# Altium schematic sheet Power_Regulation.SchDoc(Power_Regulation)
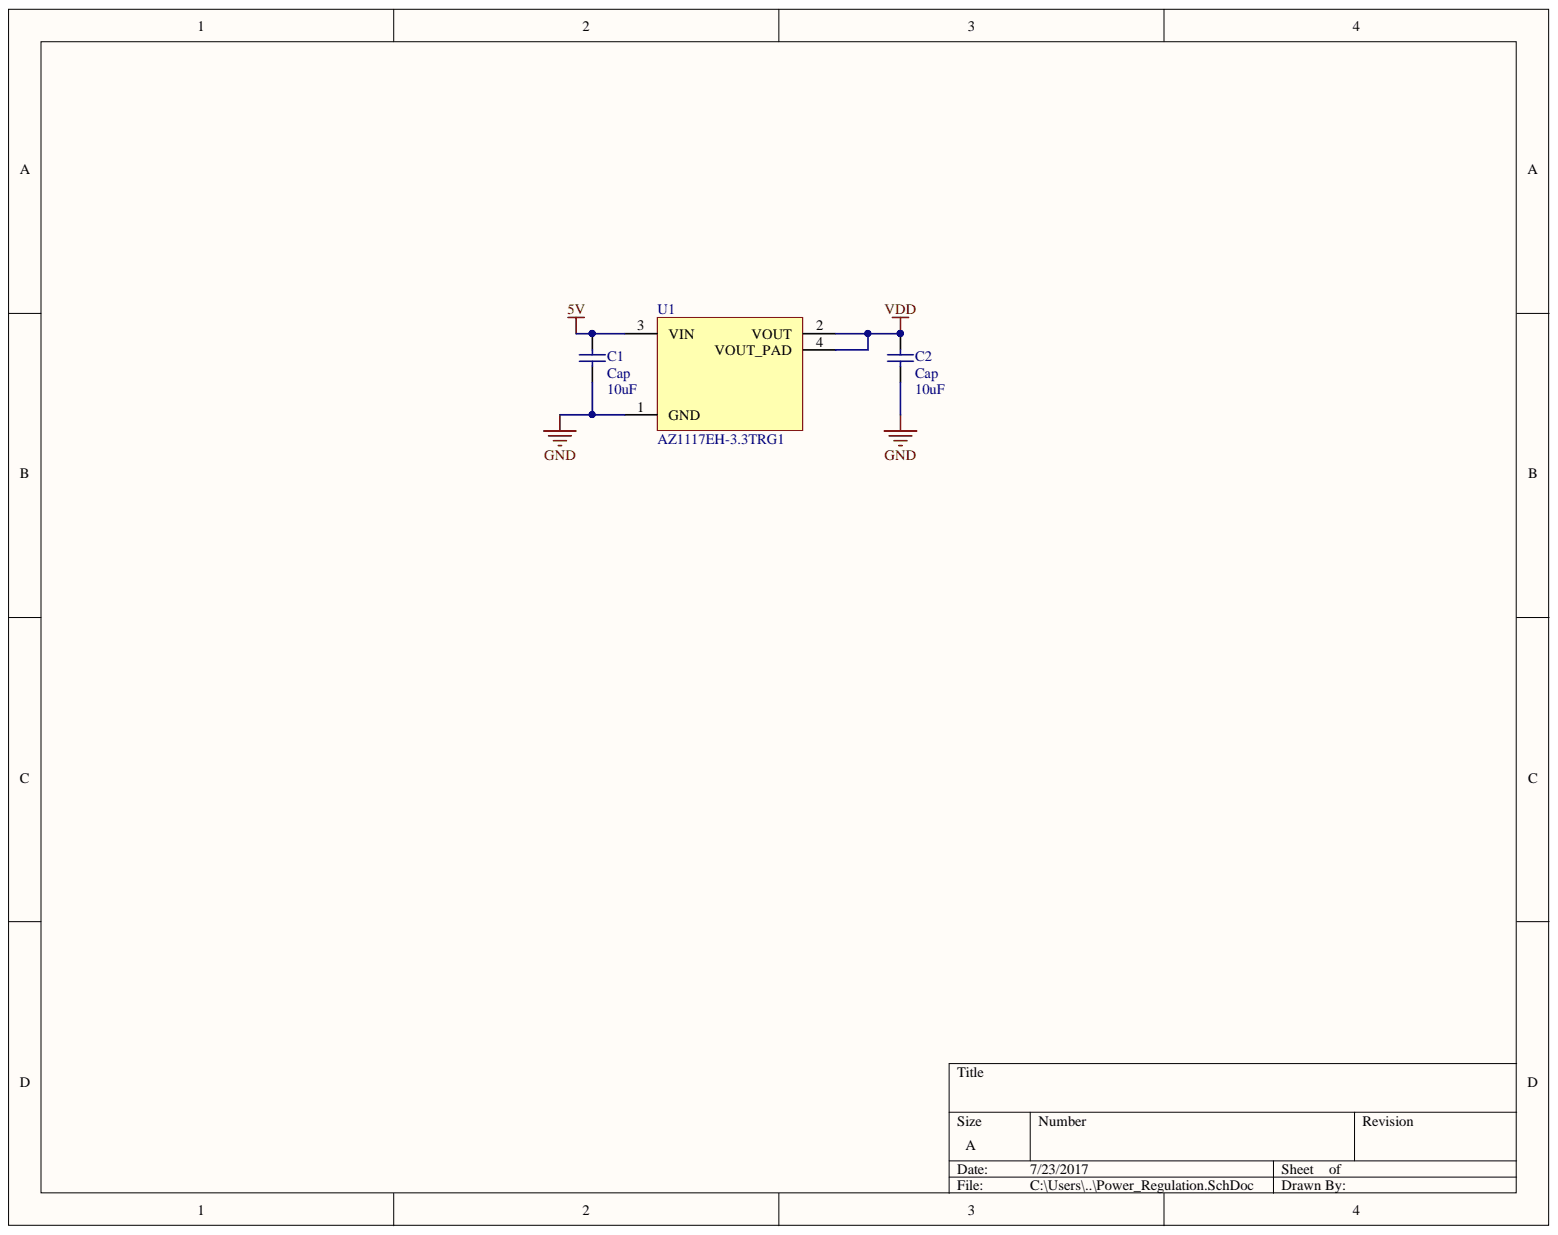
[diagram p0: Power_Regulation.SchDoc]

## Parts
C1  Cap  value 10uF  [C0805]
C2  Cap  value 10uF  [C0805]
U1  Manufacturer Part Number: AZ1117EH-3.3TRG1  [SOT223]  (hidden comment: U_AZ1117EH-3.3TRG1)

## Nets
5V: C1.2 U1.3(VIN)
GND: C1.1 C2.1 U1.1(GND)
VDD: C2.2 U1.2(VOUT) U1.4(VOUT_PAD)

## Library data of the parts
C1 C2 = Cap: Capacitor; Published: 8-Jun-2000; PackageReference: C1206; Library Name: Capacitors.IntLib; LatestRevisionNote: Re-released for DXP Platform.; LatestRevisionDate: 17-Jul-2002; Component Kind: Standard; Publisher: Altium Limited
U1 = U_AZ1117EH-3.3TRG1: IC LDO 3.3V 1A; Manufacturer: Diodes Incorporated; Voltage - Input: Up to 13V; Voltage - Dropout (Typical): 1.1V @ 1A; Regulator Topology: Positive Fixed; Number of Regulators: 1; Voltage - Output: 3.3V; Supplier Part Number 1: AZ1117EH-3.3TRG1DICT-ND; Supplier Device Package: SOT-223; Supplier 1: Digi-Key; Stock 1: 13427; RoHS: RoHS Compliant; Pricing: 1=0.61, 10=0.456, 100=0.2578, 500=0.1709, 1000=0.13108 (CAD); Packaging: Cut Tape (CT); Package / Case: TO-261-4, TO-261AA; Operating Temperature: -40°C ~ 125°C; Mounting Type: Surface Mount; Library Name: FC.SchLib; Current - Output: 1A; Current - Limit (Min): -; ComponentLink1URL: http://www.diodes.com/_files/datasheets/AZ1117E.pdf; ComponentLink1Description: http://www.diodes.com/_files/datasheets/AZ1117E.pdf; Component Kind: Standard; Category: Integrated Circuits (ICs)
